# Altium schematic sheet K210_CAM.SchDoc(K210_CAM)
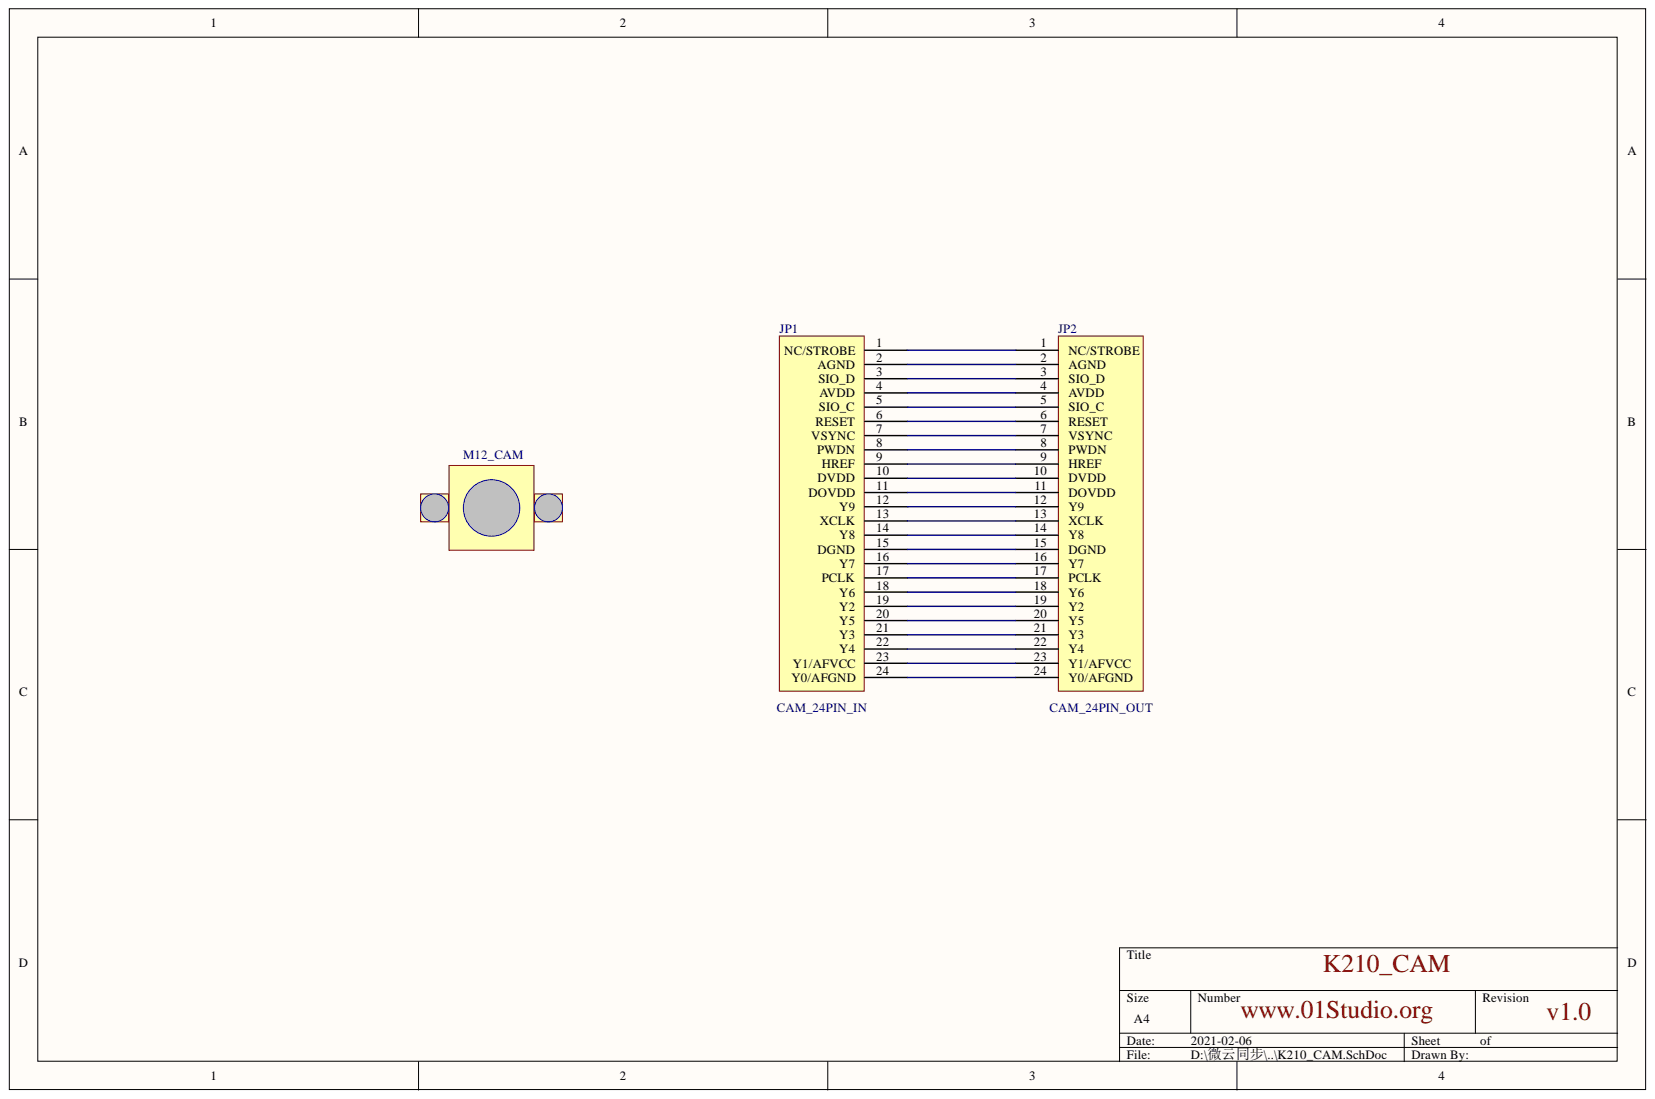
[diagram p0: K210_CAM.SchDoc]

## Parts
JP1  CAM_24PIN_IN  [FPC_24P_UP&DOWN]
JP2  CAM_24PIN_OUT  [FPC_24P_UP&DOWN]
  M12_CAM  [M12_CAM]

## Nets
NetJP1_1: JP1.1(NC/STROBE) JP2.1(NC/STROBE)
NetJP1_2: JP1.2(AGND) JP2.2(AGND)
NetJP1_3: JP1.3(SIO_D) JP2.3(SIO_D)
NetJP1_4: JP1.4(AVDD) JP2.4(AVDD)
NetJP1_5: JP1.5(SIO_C) JP2.5(SIO_C)
NetJP1_6: JP1.6(RESET) JP2.6(RESET)
NetJP1_7: JP1.7(VSYNC) JP2.7(VSYNC)
NetJP1_8: JP1.8(PWDN) JP2.8(PWDN)
NetJP1_9: JP1.9(HREF) JP2.9(HREF)
NetJP1_10: JP1.10(DVDD) JP2.10(DVDD)
NetJP1_11: JP1.11(DOVDD) JP2.11(DOVDD)
NetJP1_12: JP1.12(Y9) JP2.12(Y9)
NetJP1_13: JP1.13(XCLK) JP2.13(XCLK)
NetJP1_14: JP1.14(Y8) JP2.14(Y8)
NetJP1_15: JP1.15(DGND) JP2.15(DGND)
NetJP1_16: JP1.16(Y7) JP2.16(Y7)
NetJP1_17: JP1.17(PCLK) JP2.17(PCLK)
NetJP1_18: JP1.18(Y6) JP2.18(Y6)
NetJP1_19: JP1.19(Y2) JP2.19(Y2)
NetJP1_20: JP1.20(Y5) JP2.20(Y5)
NetJP1_21: JP1.21(Y3) JP2.21(Y3)
NetJP1_22: JP1.22(Y4) JP2.22(Y4)
NetJP1_23: JP1.23(Y1/AFVCC) JP2.23(Y1/AFVCC)
NetJP1_24: JP1.24(Y0/AFGND) JP2.24(Y0/AFGND)

## Library data of the parts
JP1 JP2 = CAM_24PIN_CONNECTOR: Component Kind: Standard
 = M12_CAM: Component Kind: Standard
